# Altium schematic sheet Power.SchDoc(Power)
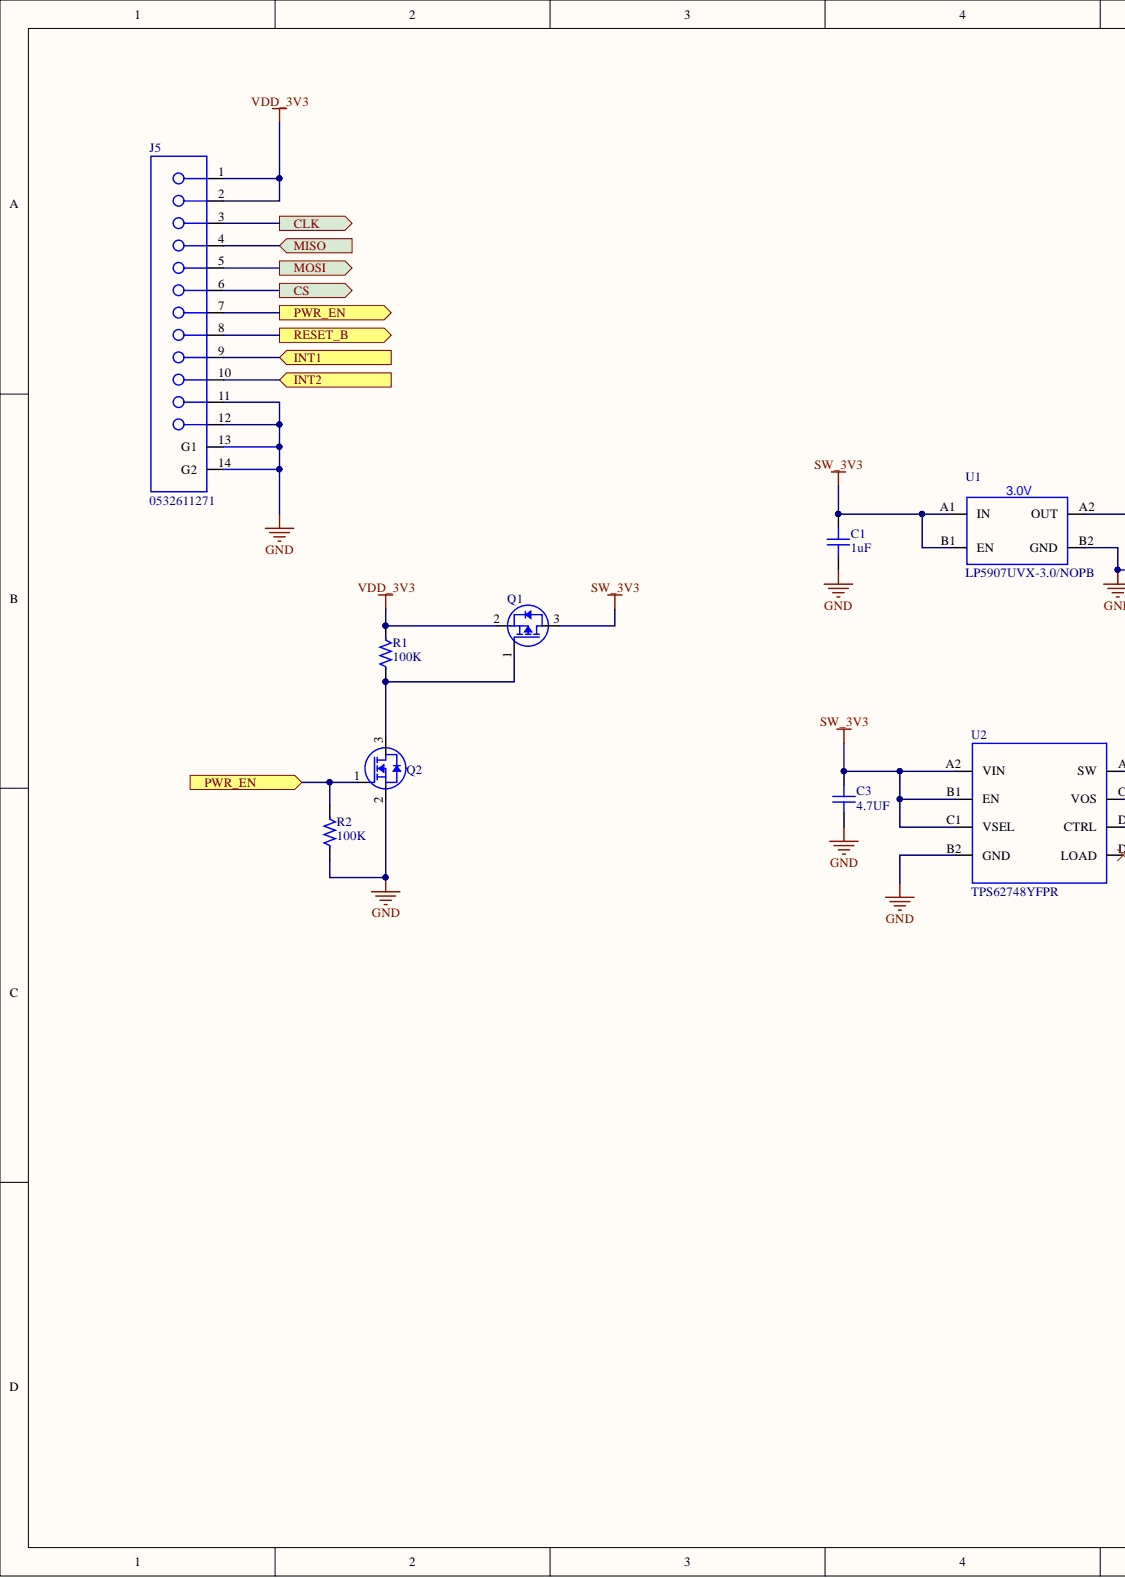
[diagram p0: Power.SchDoc, left]
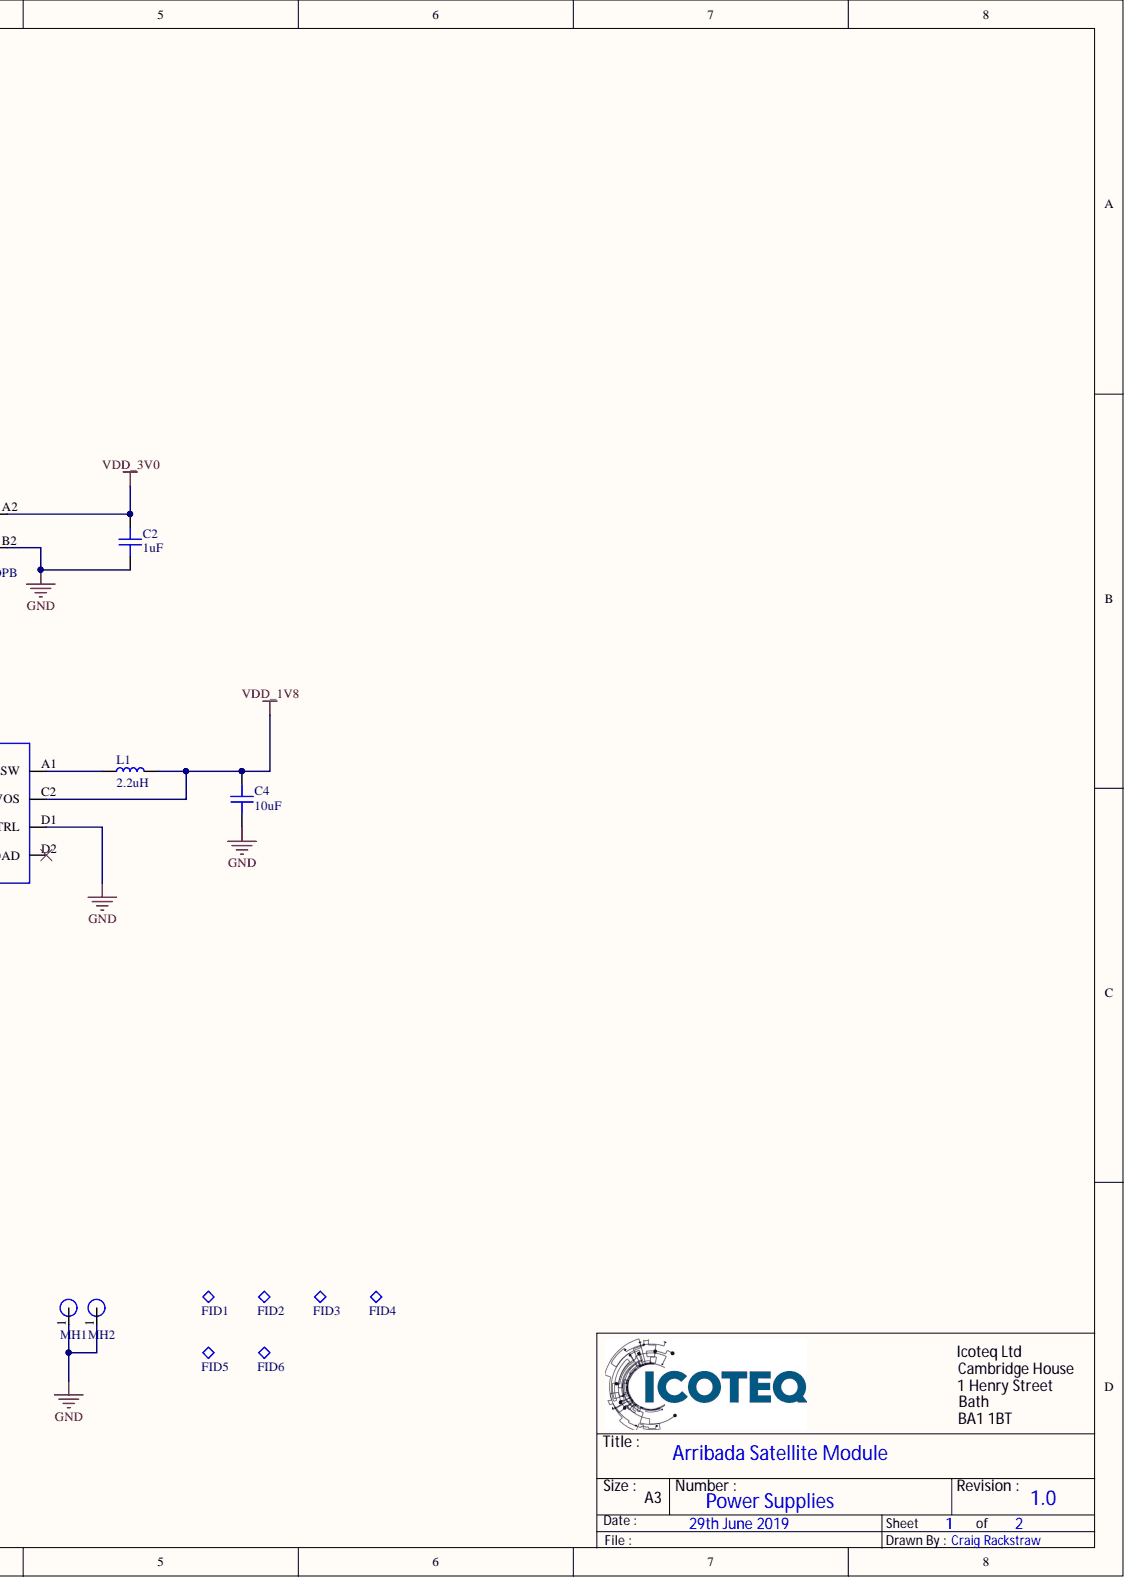
[diagram p1: Power.SchDoc, right]

## Parts
C1  value 1uF  [CAP0201L]  (hidden comment: 1UF 0201 10V 20% X5R)
C2  value 1uF  [CAP0201L]  (hidden comment: 1UF 0201 10V 20% X5R)
C3  value 4.7UF  [CAP0402L]  (hidden comment: 4.7UF 0402 10V 20% X5R)
C4  value 10uF  [CAP0402L]  (hidden comment: 10UF 0402 6.3V 20% X5R)
FID1  Fiducial  [Fiducial]  (value not drawn)
FID2  Fiducial  [Fiducial]  (value not drawn)
FID3  Fiducial  [Fiducial]  (value not drawn)
FID4  Fiducial  [Fiducial]  (value not drawn)
FID5  Fiducial  [Fiducial]  (value not drawn)
FID6  Fiducial  [Fiducial]  (value not drawn)
J5  0532611271  [0532611271]
L1  value 2.2uH  [MLZ2012]  (hidden comment: 2.2uH 20% 170mA 120MOHM 0805)
MH1  Mounting Hole M2 Plated  [Mounting Hole M2 Plated]  (value not drawn)
MH2  Mounting Hole M2 Plated  [Mounting Hole M2 Plated]  (value not drawn)
Q1  DMP21D0UFB4  [X2-DFN1006-3]  (value not drawn)
Q2  PMZ290UNE2  [DFN1006-3]  (value not drawn)
R1  value 100K  [RES0201L]  (hidden comment: 100K 0201 1% 100PPM)
R2  value 100K  [RES0201L]  (hidden comment: 100K 0201 1% 100PPM)
U1  LP5907UVX-3.0/NOPB  [DSBGA-4]
U2  TPS62748YFPR  [BGA8C40P2X4_87X156X50]

## Ports
CLK, CS, INT1, INT2, MISO, MOSI, PWR_EN, RESET_B

## Nets
GND: C1.1 C2.1 C3.1 C4.1 J5.11 J5.12 J5.13(G1) J5.14(G2) MH1.1 MH2.1 Q2.2 R2.1 U1.B2(GND) U2.B2(GND) U2.D1(CTRL)
SW_3V3: C1.2 C3.2 Q1.3 U1.A1(IN) U1.B1(EN) U2.A2(VIN) U2.B1(EN) U2.C1(VSEL)
VDD_1V8: C4.2 L1.2 U2.C2(VOS)
VDD_3V0: C2.2 U1.A2(OUT)
VDD_3V3: J5.1 J5.2 Q1.2 R1.2
CLK: J5.3 ; port CLK
CS: J5.6 ; port CS
INT1: J5.9 ; port INT1
INT2: J5.10 ; port INT2
MISO: J5.4 ; port MISO
MOSI: J5.5 ; port MOSI
PWR_EN: J5.7 Q2.1 R2.2 ; port PWR_EN
RESET_B: J5.8 ; port RESET_B
NetL1_1: L1.1 U2.A1(SW)
NetQ1_1: Q1.1 Q2.3 R1.1

Not connected: U2 D2(LOAD)

## Library data of the parts
C1 C2 = CAP CER 1UF 0201 10V 20% X5R: Manufacturers Part Number: GRM033R61A105ME15D; Manufacturer: Murata; Voltage: 10V; Tolerance: 20%; Supplier Part Number 2: 490-13226-6-ND; Supplier Part Number 1: 81-GRM033R61A105ME5D; Supplier 2: Digi-Key; Supplier 1: Mouser; Size: 0201; Library Name: Capacitors.SchLib; Dielectric: X5R; Description: CAP CER 1UF 0201 10V 20% X5R; Component Kind: Standard
C3 = CAP CER 4.7UF 0402 10V 20% X5R: Manufacturers Part Number: C1005X5R1A475M050BC; Manufacturer: TDK; Voltage: 10V; Tolerance: 20%; Supplier Part Number 2: 445-8023-1-ND; Supplier Part Number 1: 810-C1005X5R1A475M; Supplier 2: Digi-Key; Supplier 1: Mouser; Size: 0402; Library Name: Capacitors.SchLib; Dielectric: X5R; Description: CAP CER 4.7UF 0402 10V 20% X5R; Component Kind: Standard
C4 = CAP CER 10UF 0402 6.3V 20% X5R: Manufacturers Part Number: JMK105CBJ106MV-F; Manufacturer: Taiyo Yuden; Voltage: 6.3V; Tolerance: 20%; Supplier Part Number 2: 587-5868-1-ND; Supplier Part Number 1: 963-JMK105CBJ106MV-F; Supplier 2: Digi-Key; Supplier 1: Mouser; Size: 0402; Library Name: Capacitors.SchLib; Dielectric: X5R; Description: CAP CER 10UF 0402 6.3V 20% X5R; Component Kind: Standard
FID1-FID6 = Fiducial: Manufacturers Part Number: NOT FITTED PART; Manufacturer: NOT FITTED PART; Library Name: Misc.SchLib; Distributor 2: Future Electronics; Distributor 1: EBV; Description: Fiducial; Component Kind: Standard
J5 = 0532611271: CON PICOBLADE 12POS HEADER 1.25MM HORIZ SMD; Manufacturer: Molex; ALT Manufacturers Part Number: TKH12MRLSM; ALT Manufacturer: Taicom; Supplier Part Number 2: 538-53261-1271; Supplier Part Number 1: WM7630CT-ND; Supplier 2: Mouser; Supplier 1: Digi-Key; Manufacturers Part Number: 0532611271; Library Name: Connectors.SchLib; Distributor 2: Future Electronics; Distributor 1: EBV; Component Kind: Standard
L1 = 2.2uH 20% 170mA 120MOHM 0805: Manufacturer: TDK; Tolerance: 20%; Supplier Part Number 2: 445-6758-1-ND; Supplier Part Number 1: 810-MLZ2012N2R2L; Supplier 2: Digi-Key; Supplier 1: Mouser; Size: 0805; Q @ 900MHz: -; Q @ 1.8GHz: -; Manufacturers Part Number: 2.2uH 20% 170mA 120MOHM 0805; Library Name: Inductors.SchLib; Description: 2.2uH 20% 170mA 120MOHM 0805; Component Kind: Standard
MH1 MH2 = Mounting Hole M2 Plated: Manufacturers Part Number: NOT FITTED PART; Manufacturer: NOT FITTED PART; Library Name: Misc.SchLib; Distributor 2: Future Electronics; Distributor 1: EBV; Description: Mounting Hole M2 Plated; Component Kind: Standard
Q1 = DMP21D0UFB4: MOSFET ENH P-CHANNEL 0.77A CONT X2-DFN1006-3; Manufacturers Part Number: DMP21D0UFB4-7B; Manufacturer: Diodes Inc; Supplier Part Number 2: DMP21D0UFB4-7BDICT-ND; Supplier Part Number 1: 522-DMP21D0UFB4-7B; Supplier 2: Digi-Key; Supplier 1: Mouser; Library Name: Transistors.SchLib; Distributor 2 Price: 0.038; Distributor 2: Future Electronics; Distributor 1: EBV; Component Kind: Standard
Q2 = PMZ290UNE2: MOSFET ENH N-CHANNEL VDS-20V ID=1.2A RDSON=320mR DFN1006-3; Manufacturer: NXP; Supplier Part Number 2: 771-PMZ290UNE2YL; Supplier Part Number 1: 568-12503-1-ND; Supplier 2: Mouser; Supplier 1: Digi-Key; Manufacturers Part Number: PMZ290UNE2; Library Name: Transistors.SchLib; Distributor 2 Price: 0.031; Distributor 2: Future Electronics; Distributor 1: EBV; Component Kind: Standard
R1 R2 = RES 100K 0201 1% 100PPM: PPM: 100; Tolerance: 1%; Supplier Part Number 2: 541-100KAABCT-ND; Supplier Part Number 1: 71-CRCW0201100KFKED; Supplier 2: Digi-Key; Supplier 1: Mouser; Size: 0201; Library Name: Resistors.SchLib; Description: RES 100K 0201 1% 100PPM; Component Kind: Standard
U1 = LP5907UVX-3.0/NOPB: IC REG LDO 250mA 3.0V DSBGA-4; Manufacturer: Texas Instruments; Temp Min: -45; Temp Max: 125; Supplier Part Number 2: 926-LP5907UVX3.0NOPB; Supplier Part Number 1: 296-40379-1-ND; Supplier 2: Mouser; Supplier 1: Digi-Key; Manufacturers Part Number: LP5907UVX-3.0/NOPB; Library Name: ICs.SchLib; Distributor 2: Future Electronics; Distributor 1: EBV; Component Kind: Standard
U2 = TPS62748YFPR: IC VREG BUCK 300mA IP 2.15V-5.5V VOUT 1.2/1.8V; Manufacturer: Texas Instruments; Temp Min: -40; Temp Max: 85; Supplier Part Number 2: 595-TPS62748YFPR; Supplier Part Number 1: 296-52029-1-ND; Supplier 2: Mouser; Supplier 1: Digi-Key; Manufacturers Part Number: TPS62748YFPR; Library Name: ICs.SchLib; Component Kind: Standard
